# Altium schematic sheet MCU.SchDoc
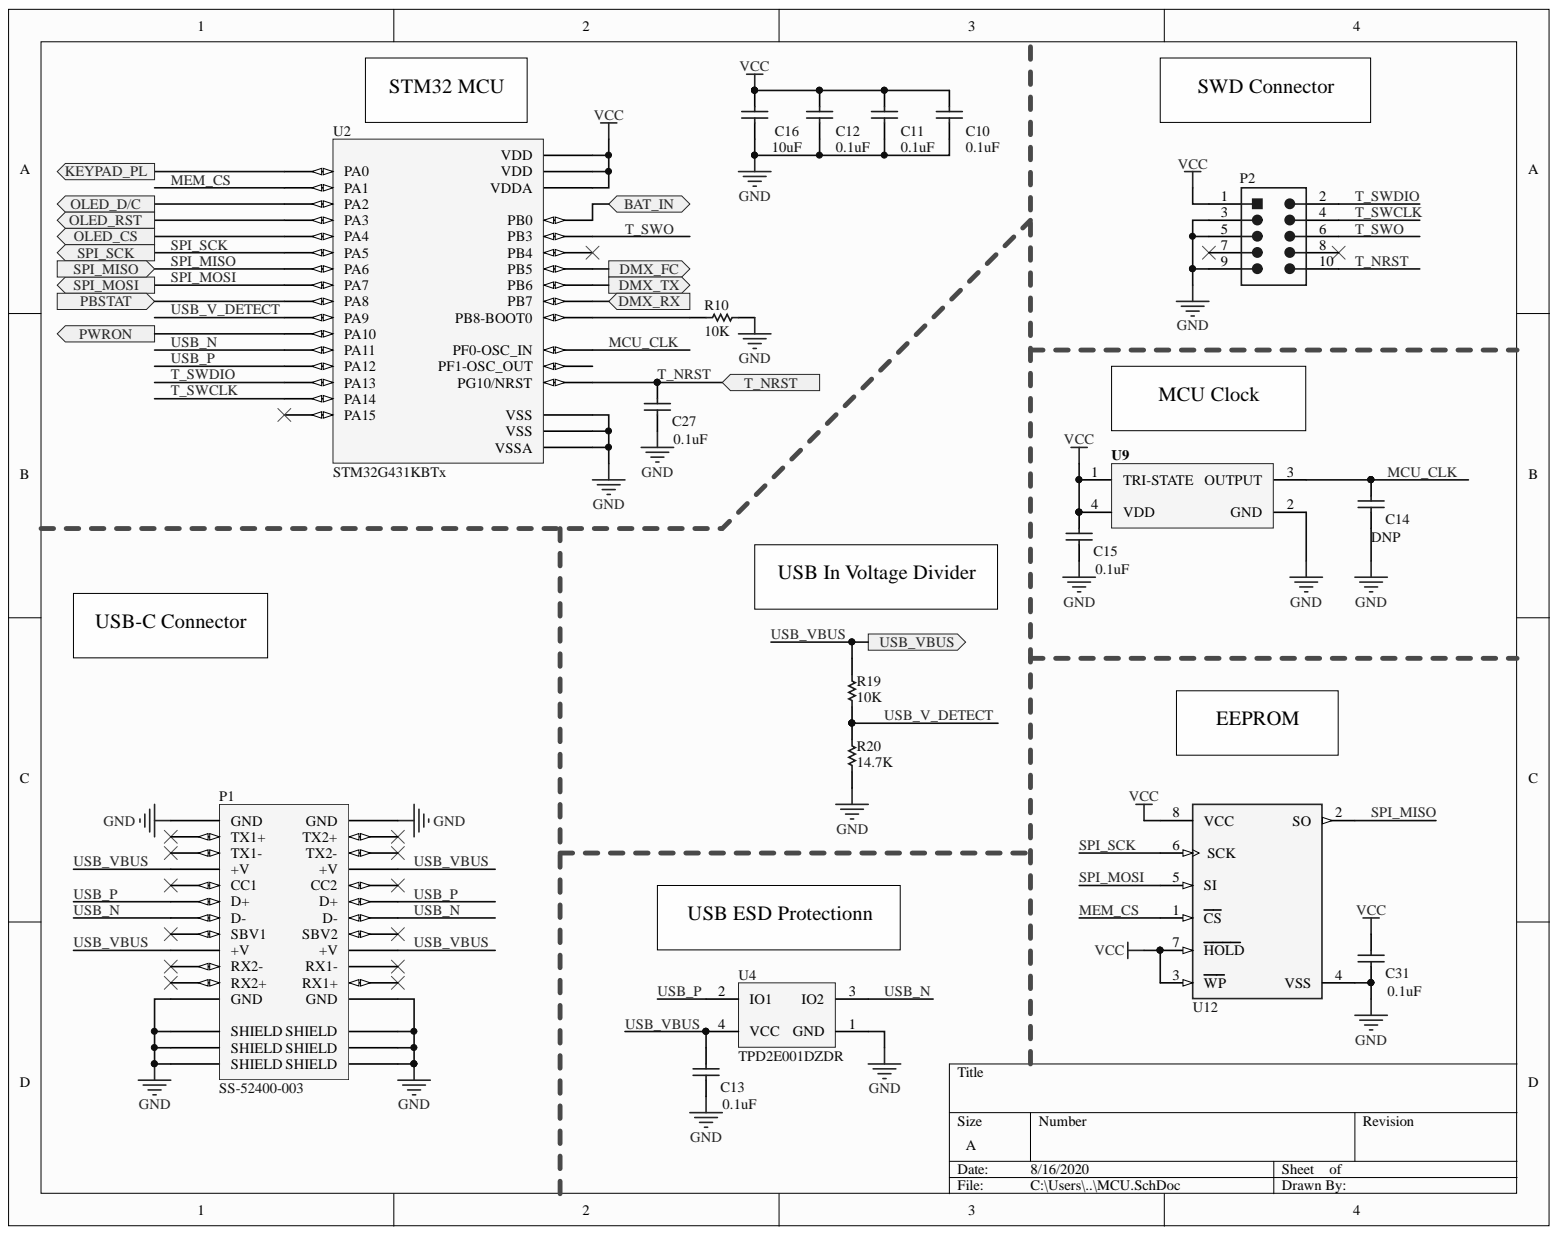
[diagram p0: MCU.SchDoc]

## Parts
C10  0.1uF  [CAPC0603(1608)100_L]
C11  0.1uF  [CAPC0603(1608)100_L]
C12  0.1uF  [CAPC0603(1608)100_L]
C13  0.1uF  [CAPC0603(1608)100_L]
C14  DNP  [CAPC0603(1608)100_L]
C15  0.1uF  [CAPC0603(1608)100_L]
C16  10uF  [CAPC0603(1608)100_L]
C27  0.1uF  [CAPC0603(1608)100_L]
C31  0.1uF  [CAPC0603(1608)100_L]
P1  SS-52400-003  [SS-52400-00X]
P2  FTSH-105-01-F-DV-K  [SMTC-FTSH-105-01-L-DV-K_V]  (value not drawn)
R10  10K  [RESC0603(1608)_N]
R19  10K  [RESC0603(1608)_N]
R20  14.7K  [RESC0603(1608)_N]
U2  STM32G431KBTx  [LQFP32]
U4  TPD2E001DZDR  [DZD0004A_N]
U9  XLH536024.000000I  [ECS-396X_V]  (value not drawn)
U12  CAT25640VI-GT3  [FP-CAT25256VI-GT3-IPC_B]  (value not drawn)

## Ports
BAT_IN, DMX_FC, DMX_RX, DMX_TX, KEYPAD_PL, OLED_CS, OLED_D/C, OLED_RST, PBSTAT, PWRON, SPI_MISO, SPI_MOSI, SPI_SCK, T_NRST, USB_VBUS

## Nets
GND: C10.1 C11.1 C12.1 C13.1 C14.1 C15.1 C16.1 C27.1 C31.1 P1.A1(GND) P1.A12(GND) P1.B1(GND) P1.B12(GND) P1.G1(SHIELD) P1.G2(SHIELD) P1.G3(SHIELD) P1.G4(SHIELD) P1.G5(SHIELD) P1.G6(SHIELD) P2.3 P2.5 P2.9 R10.2 R20.1 U2.14(VSSA) U2.16(VSS) U2.32(VSS) U4.1(GND) U9.2(GND) U12.4(VSS)
VCC: C10.2 C11.2 C12.2 C15.2 C16.2 C31.2 P2.1 U2.1(VDD) U2.15(VDDA) U2.17(VDD) U9.1(TRI-STATE) U9.4(VDD) U12.3(WP) U12.7(HOLD) U12.8(VCC)
BAT_IN: U2.13(PB0) ; port BAT_IN
DMX_FC: U2.28(PB5) ; port DMX_FC
DMX_RX: U2.30(PB7) ; port DMX_RX
DMX_TX: U2.29(PB6) ; port DMX_TX
KEYPAD_PL: U2.5(PA0) ; port KEYPAD_PL
MCU_CLK: C14.2 U2.2(PF0-OSC_IN) U9.3(OUTPUT)
MEM_CS: U2.6(PA1) U12.1(CS)
OLED_CS: U2.9(PA4) ; port OLED_CS
OLED_D/C: U2.7(PA2) ; port OLED_D/C
OLED_RST: U2.8(PA3) ; port OLED_RST
PBSTAT: U2.18(PA8) ; port PBSTAT
PWRON: U2.20(PA10) ; port PWRON
SPI_MISO: U2.11(PA6) U12.2(SO) ; port SPI_MISO
SPI_MOSI: U2.12(PA7) U12.5(SI) ; port SPI_MOSI
SPI_SCK: U2.10(PA5) U12.6(SCK) ; port SPI_SCK
T_NRST: C27.2 P2.10 U2.4(PG10/NRST) ; port T_NRST
T_SWCLK: P2.4 U2.24(PA14)
T_SWDIO: P2.2 U2.23(PA13)
T_SWO: P2.6 U2.26(PB3)
USB_N: P1.A7(D-) P1.B7(D-) U2.21(PA11) U4.3(IO2)
USB_P: P1.A6(D+) P1.B6(D+) U2.22(PA12) U4.2(IO1)
USB_V_DETECT: R19.2 R20.2 U2.19(PA9)
USB_VBUS: C13.2 P1.A4(+V) P1.A9(+V) P1.B4(+V) P1.B9(+V) R19.1 U4.4(VCC) ; port USB_VBUS
NetP1_A2: P1.A2(TX1+)
NetP1_A3: P1.A3(TX1-)
NetP1_A5: P1.A5(CC1)
NetP1_A8: P1.A8(SBV1)
NetP1_A10: P1.A10(RX2-)
NetP1_A11: P1.A11(RX2+)
NetP1_B2: P1.B2(TX2+)
NetP1_B3: P1.B3(TX2-)
NetP1_B5: P1.B5(CC2)
NetP1_B8: P1.B8(SBV2)
NetP1_B10: P1.B10(RX1-)
NetP1_B11: P1.B11(RX1+)
NetP2_7: P2.7
NetP2_8: P2.8
NetR10_1: R10.1 U2.31(PB8-BOOT0)
NetU2_3: U2.3(PF1-OSC_OUT)
NetU2_25: U2.25(PA15)
NetU2_27: U2.27(PB4)

## Library data of the parts
C10-C16 C27 C31 = CMP-1035-00873-1: CAP 27pF 16V ±0.5pF 0603 (1608 Metric) Thickness 1mm SMD; Case-EIA: 0603; Case-Metric: 1608; Tolerance: Â±0.5pF; Component Kind: Standard; Library Name: Altium Content Vault; Max Thickness: 1 mm; Rated Voltage: 16 V; Technology: SMT; Value: 27pF
P2 = CMP-2000-05352-1: Male Header, Pitch 1.27 mm, 2 x 5 Position, Height 6.12 mm, RoHS, Tube; Manufacturer: Samtec; Connector Type: Header,Shrouded; Number of Positions: 10; Number of Positions Loaded: All; Pitch: 0.050"(1.27mm); Category: Connectors,Interconnects; Color: Black; Component Kind: Standard; Contact Finish: Gold; Contact Finish Thickness: 3µin(0.08µm); Contact Mating Length: 0.120"(3.05mm); Contact Type: MalePin; Datasheet URL: http://suddendocs.samtec.com/prints/ftsh-1xx-xx-xxx-dv-xxx-mkt.pdf; Datasheet Version: Rev. ET, 10/1995; Family: RectangularConnectors-Headers,MalePins; Fastening Type: -; Features: -; Library Name: Altium Content Vault; Manufacturer URL: http://www.samtec.com/; Mounting Type: SurfaceMount; Number of Rows: 2; Package Description: Male Header, Pitch 1.27 mm, 2 x 5 Position, Height 5.97 mm, Tail Length 4.32 mm; Package Reference: FTSH-105-01-L-DV-K; Package URL: http://suddendocs.samtec.com/prints/ftsh-1xx-xx-xxx-dv-xxx-footprint.pdf; Packaging: Tube; Row Spacing: 0.050"(1.27mm); Series: FTSH; Termination: Solder
R10 R19 R20 = CMP-1012-00001-1: Jumper 0603 (1608 Metric); Case-EIA: 0603; Case-Metric: 1608; Power: None; Value: 0.00 Ohm; Component Kind: Standard; Library Name: Altium Content Vault; Technology: SMT; Tolerance: None
U4 = CMP-2000-04961-1: Low-Capacitance 2-Channel ESD-Protection Array for High-Speed Data Interface, 0.9 to 5.5 V, -40 to 85 degC, 4-Pin SOP (DZD), Green (RoHS & no Sb/Br), Tape and Reel; Manufacturer: Texas Instruments; Manufacturer Product Page: TPD2E001DZDRSpecifications; Bidirectional Channels: 2; EDA / CAD Models: DownloadfromAcceleratedDesigns; Online Catalog: TPD2ESeries; Other Names: 296-24770-6; Power Line Protection: Yes; Product Training Modules: ESDProtectionBasics; Voltage - Breakdown (Min): 11V; Voltage - Reverse Standoff (Typ): 5.5V(Max); Applications: Ethernet; Capacitance @ Frequency: -; Category: CircuitProtection; Component Kind: Standard; Current - Peak Pulse (10/1000µs): -; Datasheet URL: http://www.ti.com/general/docs/lit/getliterature.tsp?genericPartNumber=TPD2E001&fileType=pdf; Datasheet Version: Rev. H, 08/2014; Family: TVS-Diodes; Library Name: Altium Content Vault; Manufacturer URL: http://www.ti.com/; Mounting Type: SurfaceMount; Operating Temperature: -40degC~85degC(TA); Package / Case: TO-253-4,TO-253AA; Package Description: 4-Pin Small Outline Package, Body 2.92 x 1.3 mm, Pitch 1.92 mm; Package Reference: DZD4; Packaging: Digi-Reel; Power - Peak Pulse: -; Series: -; Standard Package: 1; Supplier Device Package: 4-SOP; Type: Steering(RailtoRail); Unidirectional Channels: -; Voltage - Clamping (Max) @ Ipp: -
U9 = CMP-2000-06192-1: Clock Oscillator, 3.3 V, 25 MHz, -55 to 125 degC, 4-Pin SMD, RoHS, Tape and Reel; Manufacturer: ECS; Frequency: 25Hz; Frequency Stability: 100PPM; Load Capacitance: 30pF; Output Format: HCMOS; Supply Voltage: 3.3V; Component Kind: Standard; Datasheet URL: http://www.mouser.com/ds/2/122/ecs-3961_3963-18682.pdf; Datasheet Version: 04/2012; Dimensions: 3.2mmWx5mmL; Library Name: Altium Content Vault; Manufacturer URL: https://www.ecsxtal.com/; Maximum Operating Temperature: +125C; Minimum Operating Temperature: -55C; Mounting Technology: SurfaceMount; Package / Case: 5mmx3.2mm; Package Description: 4-Pin Surface Mount Device, Body 5 x 3.2 mm, Pitch 2.54 mm; Package Reference: 396X; Packaging: Reel; Product: SMDOscillators; RoHS: Details; Series: ECS3963; Termination Style: SMD/SMT
U12 = CMP-07117-000003-1: IC EEPROM 256K SPI 20MHZ 8SOIC; Manufacturer: ON Semiconductor; Manufacturer Part Number: CAT25256VI-GT3; Max Supply Voltage: 5.5V; Memory Size: 256Kbits; Min Supply Voltage: 1.8V; Nominal Supply Current: 2-4mA; Standoff Height: 0.1mm; Automotive: No; Category: IC; Component Kind: Standard; ComponentLink2Description: Datasheet; ComponentLink2URL: https://www.onsemi.cn/PowerSolutions/document/CAT25256-D.PDF; Device Class L1: Integrated Circuits (ICs); Device Class L2: Memory; Device Class L3: EEPROM; Digikey Description: IC EEPROM 256K SPI 20MHZ 8SOIC; Footprint Url: https://www.onsemi.com/pub/Collateral/751BD.PDF; Frequency: 20MHz; Height: 1.75mm; Interface: SPI; Ipc Land Pattern Name: SOIC127P600X155-8; Lead Free: Yes; Library Name: Altium Content Vault; Memory Type: EEPROM; Mouser Description: EEPROM Serial-SPI 256K-bit 32K x 8 2.5V/3.3V/5V 8-Pin SOIC N T/R; Octopart: https://octopart.com/cat25256vi-gt3-on+semiconductor-10567745; Package: SOIC8; RoHS: TRUE; Temperature: -40°C to +85°C; Temperature Range High: +85°C; Temperature Range Low: -40°C
P1 = SS-52400-003: Component Kind: Standard; Library Name: Belfuse.SchLib
U2 = STM32G431KBTx: Component Kind: Standard; Library Name: STMicroelectronics.SchLib
